# Altium schematic sheet RS485.SchDoc(RS485)
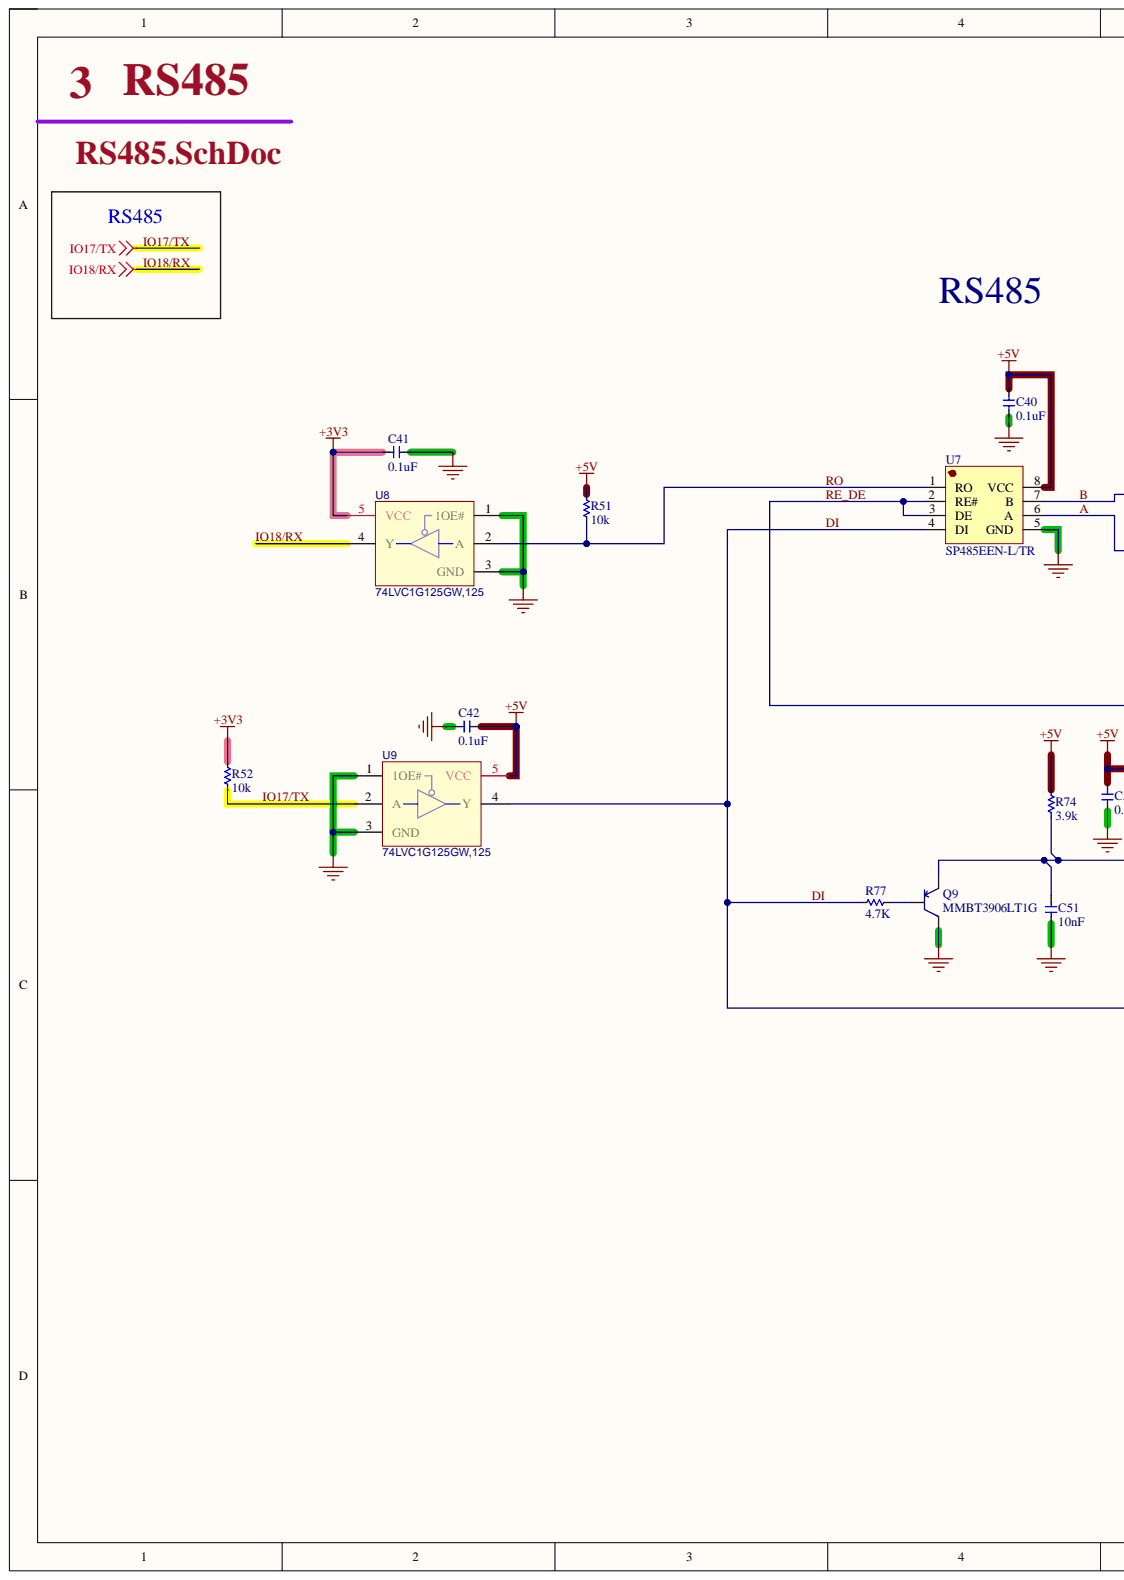
[diagram p0: RS485.SchDoc, left]
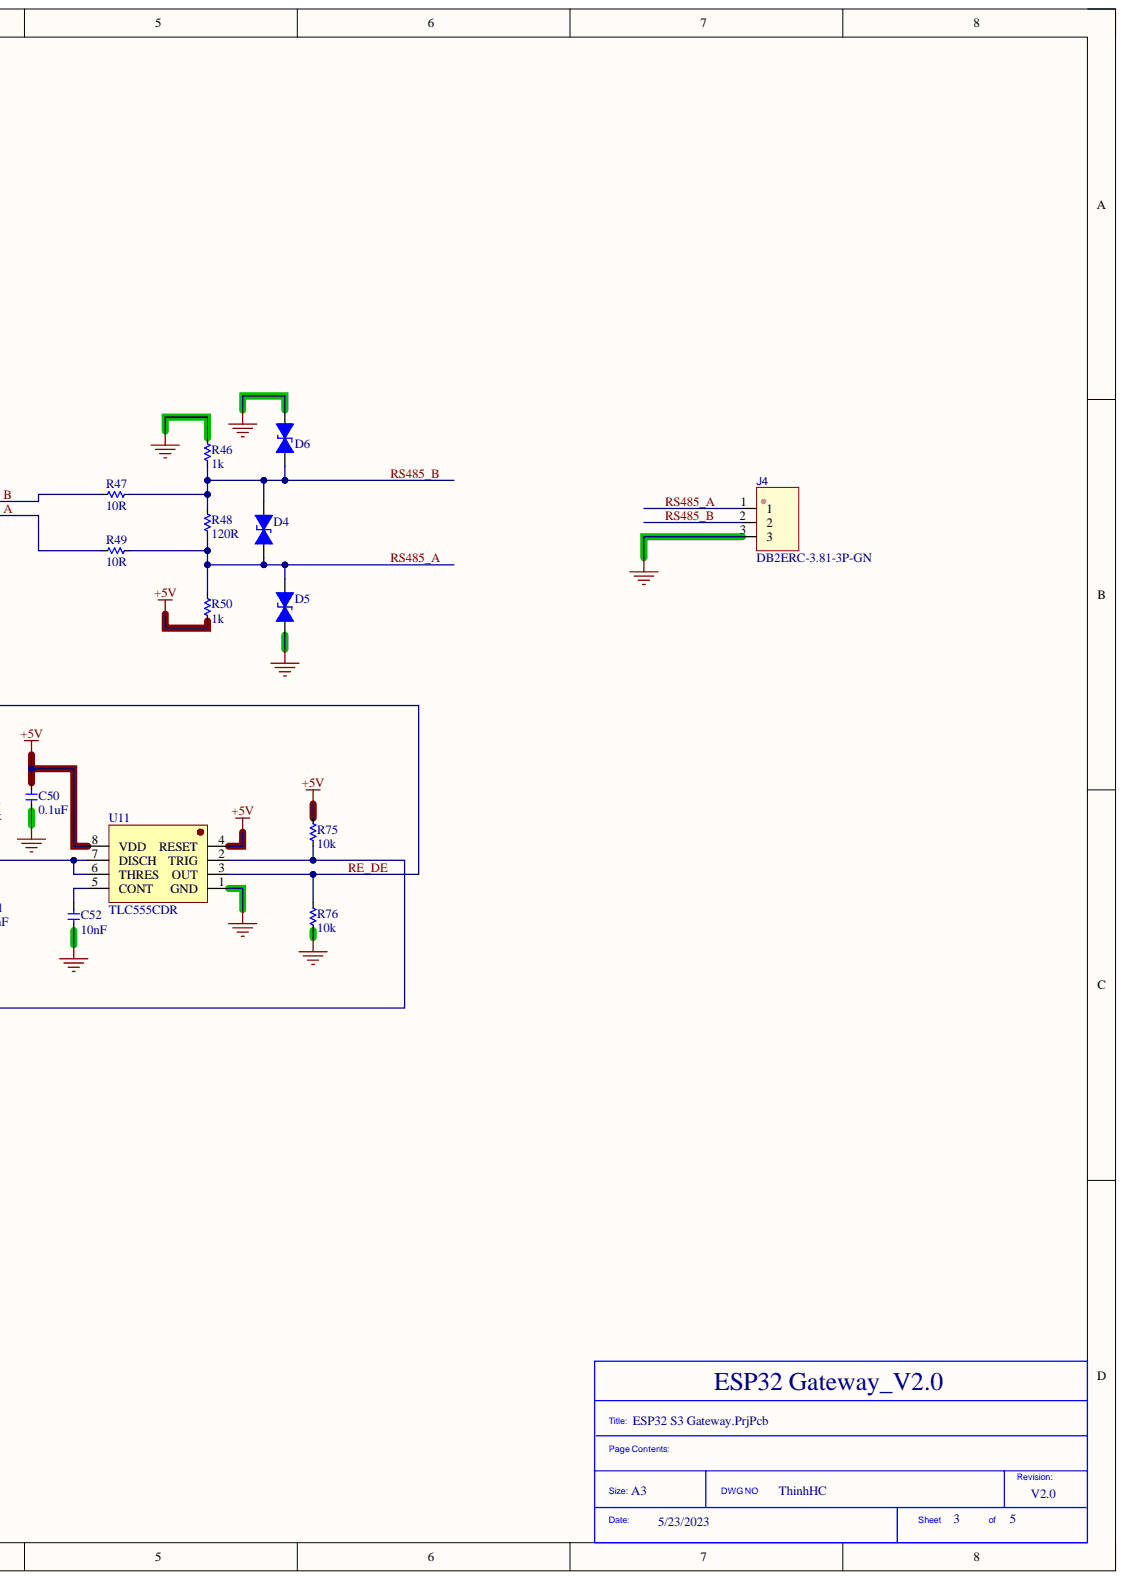
[diagram p1: RS485.SchDoc, right]

## Parts
C40  0.1uF  [C_0402]
C41  0.1uF  [C_0402]
C42  0.1uF  [C_0402]
C50  0.1uF  [C_0402]
C51  10nF  [C_0402]
C52  10nF  [C_0402]
D4  SMAJ12CA  [SMA,DO-214AC - CA]  (value not drawn)
D5  SMAJ12CA  [SMA,DO-214AC - CA]  (value not drawn)
D6  SMAJ12CA  [SMA,DO-214AC - CA]  (value not drawn)
J4  DB2ERC-3.81-3P-GN  [CONN-TH_6P-P3.81_DB2ERC-3.81-3P]
Q9  MMBT3906LT1G  [SOT-23-3]
R46  1k  [R_0603]
R47  10R  [R_0603]
R48  120R  [R_0603]
R49  10R  [R_0603]
R50  1k  [R_0603]
R51  10k  [R_0402]
R52  10k  [R_0402]
R74  3.9k  [R_0402]
R75  10k  [R_0402]
R76  10k  [R_0402]
R77  4.7K  [R_0402]
U7  SP485EEN-L/TR  [SOIC-8]
U8  74LVC1G125GW,125  [SOT-353_L2.1-W1.3-P0.65-LS2.3-BR]
U9  74LVC1G125GW,125  [SOT-353_L2.1-W1.3-P0.65-LS2.3-BR]
U11  TLC555CDR  [SOIC-8]

## Nets
+3V3: C41.2 R52.2 U8.5(VCC)
+5V: C40.2 C42.1 C50.2 R50.1 R51.2 R74.1 R75.2 U7.8(VCC) U9.5(VCC) U11.4(RESET) U11.8(VDD)
GND: C40.1 C41.1 C42.2 C50.1 C51.1 C52.1 D5.1 D6.1 J4.3 Q9.3 R46.2 R76.1 U7.5(GND) U8.1(1OE#) U8.3(GND) U9.1(1OE#) U9.3(GND) U11.1(GND)
A: R49.1 U7.6(A)
B: R47.1 U7.7(B)
DI: R75.1 R77.1 U7.4(DI) U9.4(Y) U11.2(TRIG)
IO17/TX: R52.1 U9.2(A)
IO18/RX: U8.4(Y)
RE_DE: R76.2 U7.2(RE#) U7.3(DE) U11.3(OUT)
RO: R51.1 U7.1(RO) U8.2(A)
RS485_A: D4.1 D5.2 J4.1 R48.1 R49.2 R50.2
RS485_B: D4.2 D6.2 J4.2 R46.1 R47.2 R48.2
NetC51_2: C51.2 Q9.2 R74.2 U11.6(THRES) U11.7(DISCH)
NetC52_2: C52.2 U11.5(CONT)
NetQ9_1: Q9.1 R77.2

## Library data of the parts
C40-C42 C50 = CL05B104KB54PNC: 50V 100nF X7R ±10% 0402 Multilayer Ceramic Capacitors MLCC - SMD/SMT ROHS; Manufacturer: Samsung Electro-Mechanics; Assembly Type: SMT Assembly; Component Kind: Standard; ComponentLink1Description: Digikey Link; ComponentLink1URL: https://www.digikey.com/en/products/detail/yageo/CC0603KRX7R9BB104/2103082?s=N4IgTCBcDaIMwEYEFoFwCzuWZA5AIiALoC%2BQA; ComponentLink2Description: LCSC Link; ComponentLink2URL: https://jlcpcb.com/parts/componentSearch?searchTxt=10k%200603; LCSC Part Number: C307331; Library Name: ESP32 S3 Gateway.SchLib; Manufacturer Part Number: CL05B104KB54PNC; Package: 0402
C51 C52 = CL05B103KB5NNNC: 10nF 50V X7R ±10% 0402 Multilayer Ceramic Capacitors MLCC - SMD/SMT ROHS; Manufacturer: Samsung Electro-Mechanics; Assembly Type: SMT Assembly; Component Kind: Standard; ComponentLink1Description: Digikey Link; ComponentLink1URL: https://www.digikey.com/en/products/detail/yageo/CC0603KRX7R9BB104/2103082?s=N4IgTCBcDaIMwEYEFoFwCzuWZA5AIiALoC%2BQA; ComponentLink2Description: LCSC Link; ComponentLink2URL: https://jlcpcb.com/parts/componentSearch?searchTxt=10k%200603; LCSC Part Number: C15195; Library Name: ESP32 S3 Gateway.SchLib; Manufacturer Part Number: CL05B103KB5NNNC; Package: 0402
D4-D6 = SMAJ12CA: 1uA 20.1A 19.9V 13.3V 12V 15.3V Bi-Directional SMA(DO-214AC) TVS ROHS; Manufacturer: RUILON(Shenzhen Ruilongyuan Elec); Assembly Type: SMT Assembly; Component Kind: Standard; LCSC Part Number: C32700; Library Name: ESP32 Gateway_V2.0.SchLib; Manufacturer Part Number: SMAJ12CA; Package: SMA(DO-214AC)
J4 = DB2ERC-3.81-3P-GN: 3.81mm 1x3P Green Board Edge/Receptacle-Close Push-Pull,P=3.81mm Pluggable System Terminal Block ROHS; Manufacturer: DIBO; Assembly Type: Hand Soldering; Component Kind: Standard; LCSC Part Number: C395690; Library Name: ESP32 S3 Gateway.SchLib; Manufacturer Part Number: DB2ERC-3.81-3P-GN; Package: Push-Pull,P=3.81mm
Q9 = MMBT3906LT1G: MMBT3906 TRANSISTORS (NPN/PNP) PNP 200MA 40V SOT-23(SOT-23-3) ROHS; Manufacturer: ON Semicon; Assembly Type: SMT Assembly; Component Kind: Standard; LCSC Part Number: C53444; Library Name: ESP32 Gateway_V2.0.SchLib; Manufacturer Part Number: MMBT3906LT1G; Package: SOT-23-3
R46 R50 = 0603WAF1001T5E: 100mW Thick Film Resistors 75V ±100ppm/℃ ±1% -55℃~+155℃ 1kΩ 0603 Chip Resistor - Surface Mount ROHS; Manufacturer: UNI-ROYAL(Uniroyal Elec); Assembly Type: SMT Assembly; Component Kind: Standard; LCSC Part Number: C21190; Library Name: ESP32 Gateway_V2.0.SchLib; Manufacturer Part Number: 0603WAF1001T5E; Package: 0603
R47 R49 = 0603WAF100JT5E: 100mW Thick Film Resistors 75V ±1% ±400ppm/℃ -55℃~+155℃ 10Ω 0603 Chip Resistor - Surface Mount ROHS; Manufacturer: UNI-ROYAL(Uniroyal Elec); Assembly Type: SMT Assembly; Component Kind: Standard; LCSC Part Number: C22859; Library Name: ESP32 Gateway_V2.0.SchLib; Manufacturer Part Number: 0603WAF100JT5E; Package: 0603
R48 = 0603WAF1200T5E: 100mW Thick Film Resistors 75V ±100ppm/℃ ±1% -55℃~+155℃ 120Ω 0603 Chip Resistor - Surface Mount ROHS; Manufacturer: UNI-ROYAL(Uniroyal Elec); Assembly Type: SMT Assembly; Component Kind: Standard; LCSC Part Number: C22787; Library Name: ESP32 Gateway_V2.0.SchLib; Manufacturer Part Number: 0603WAF1200T5E; Package: 0603
R51 R52 R75 R76 = 0402WGF1002TCE: 10kΩ 1% 0402 Chip Resistor - Surface Mount ROHS; Manufacturer: UNI-ROYAL(Uniroyal Elec); Assembly Type: SMT Assembly; Component Kind: Standard; LCSC Part Number: C25744; Library Name: ESP32 S3 Gateway.SchLib; Manufacturer Part Number: 0402WGF1002TCE; Package: 0402
R74 = RC-02W3901FT: 3.9kΩ 0402 1%  Chip Resistor - Surface Mount ROHS; Manufacturer: FH (Guangdong Fenghua Advanced Tech); Assembly Type: SMT Assembly; Component Kind: Standard; LCSC Part Number: C321486; Library Name: ESP32 S3 Gateway.SchLib; Manufacturer Part Number: RC-02W3901FT; Package: 0402
R77 = 0402WGF4701TCE: 62.5mW Thick Film Resistors ±1% 4.7kΩ 0402 Chip Resistor - Surface Mount ROHS; Manufacturer: UNI-ROYAL(Uniroyal Elec); Assembly Type: SMT Assembly; Component Kind: Standard; LCSC Part Number: C25900; Library Name: ESP32 S3 Gateway.SchLib; Manufacturer Part Number: 0402WGF4701TCE; Package: 0402
U7 = SP485EEN-L/TR: transceiver 4.75V~5.25V 10Mbps 1/1 SOIC-8_150mil RS-485/RS-422 ICs ROHS; Manufacturer: MaxLinear; Assembly Type: SMT Assembly; Component Kind: Standard; LCSC Part Number: C6855; Library Name: ESP32 Gateway_V2.0.SchLib; Manufacturer Part Number: SP485EEN-L/TR; Package: SOIC-8
U8 U9 = 74LVC1G125GW,125: 74LVC1G125GW,125 32mA 1.65V~5.5V 32mA SOT-353  Buffer/Driver/Transceiver ROHS; Manufacturer: Nexperia; Assembly Type: SMT Assembly; Component Kind: Standard; LCSC Part Number: C12519; Library Name: ESP32 Gateway_V2.0.SchLib; Manufacturer Part Number: 74LVC1G125GW,125; Package: SOT-353
U11 = TLC555CDR: SOIC-8 Timers / Clock Oscillators ROHS; Manufacturer: Texas Instruments; Assembly Type: SMT Assembly; Component Kind: Standard; LCSC Part Number: C6986; Library Name: ESP32 Gateway_V2.0.SchLib; Manufacturer Part Number: TLC555CDR; Package: SOIC-8
